# Altium schematic sheet MotorCtrl.SchDoc(MotorCtrl)
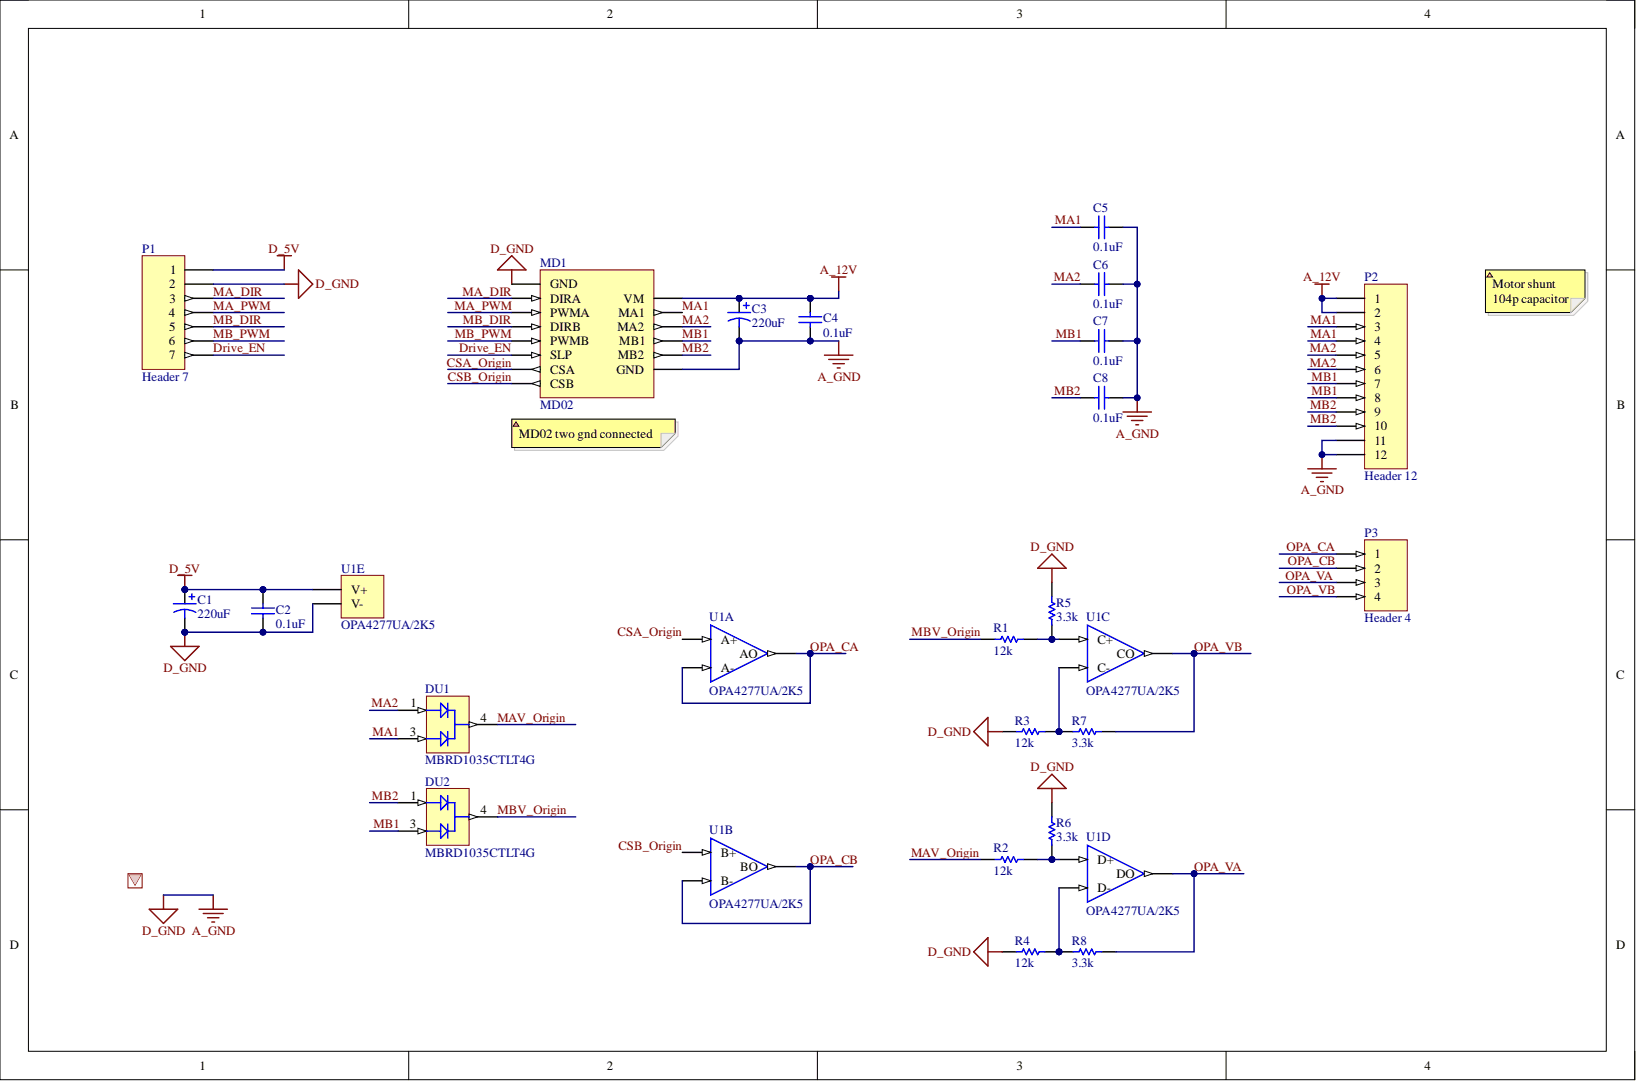
[diagram p0: MotorCtrl.SchDoc]

## Parts
C1  220uF  [VZT221M1ETR-0607]
C2  0.1uF  [CL10B104KA8NNNC]
C3  220uF  [VZT221M1ETR-0607]
C4  0.1uF  [CL10B104KA8NNNC]
C5  0.1uF  [CL10B104KA8NNNC]
C6  0.1uF  [CL10B104KA8NNNC]
C7  0.1uF  [CL10B104KA8NNNC]
C8  0.1uF  [CL10B104KA8NNNC]
DU1  MBRD1035CTLT4G  [MBRD1035CTLT4G]
DU2  MBRD1035CTLT4G  [MBRD1035CTLT4G]
MD1  MD02  [MD02]
P1  Header 7  [KH-2.54PH180-1X7P-L11.5]
P2  Header 12  [KH-2.54PH180-1X12P-L11.5 (Pad Linked)]
P3  Header 4  [KH-2.54PH180-1X4P-L11.5]
R1  12k  [PTFR0603B12K0N9]
R2  12k  [PTFR0603B12K0N9]
R3  12k  [PTFR0603B12K0N9]
R4  12k  [PTFR0603B12K0N9]
R5  3.3k  [0603WAF3301T5E]
R6  3.3k  [0603WAF3301T5E]
R7  3.3k  [0603WAF3301T5E]
R8  3.3k  [0603WAF3301T5E]
U1  OPA4277UA/2K5  [OPA4277UA/2K5]  (parts A,B,C,D,E)

## Nets
A_12V: C3.1 C4.2 MD1.9(VM) P2.1 P2.2
A_GND: C1.2 C2.1 C3.2 C4.1 C5.1 C6.1 C7.1 C8.1 MD1.1(GND) MD1.14(GND) P1.2 P2.11 P2.12 R3.2 R4.2 R5.2 R6.2 U1.11(V-)
D_5V: C1.1 C2.2 P1.1 U1.4(V+)
CSA_Origin: MD1.7(CSA) U1.3(A+)
CSB_Origin: MD1.8(CSB) U1.5(B+)
Drive_EN: MD1.6(SLP) P1.7
MA1: C5.2 DU1.3 MD1.10(MA1) P2.3 P2.4
MA2: C6.2 DU1.1 MD1.11(MA2) P2.5 P2.6
MA_DIR: MD1.2(DIRA) P1.3
MA_PWM: MD1.3(PWMA) P1.4
MAV_Origin: DU1.4 R2.2
MB1: C7.2 DU2.3 MD1.12(MB1) P2.7 P2.8
MB2: C8.2 DU2.1 MD1.13(MB2) P2.9 P2.10
MB_DIR: MD1.4(DIRB) P1.5
MB_PWM: MD1.5(PWMB) P1.6
MBV_Origin: DU2.4 R1.2
OPA_CA: P3.1 U1.1(AO) U1.2(A-)
OPA_CB: P3.2 U1.6(B-) U1.7(BO)
OPA_VA: P3.3 R8.1 U1.14(DO)
OPA_VB: P3.4 R7.1 U1.8(CO)
NetR1_1: R1.1 R5.1 U1.10(C+)
NetR2_1: R2.1 R6.1 U1.12(D+)
NetR3_1: R3.1 R7.2 U1.9(C-)
NetR4_1: R4.1 R8.2 U1.13(D-)

## Library data of the parts
C1 C3 = Cap Pol1: -45℃~+105℃ 2000hrs@105℃ 220uF 7.7mm 25V 6.3mm ±20% SMD,6.3x7.7mm  Aluminum Electrolytic Capacitors - SMD ROHS; Component Kind: Standard; LatestRevisionDate: 17-Jul-2002; LatestRevisionNote: Re-released for DXP Platform.; Library Name: Miscellaneous Devices.IntLib; PackageDescription: Polarized Capacitor (Radial); 2 Leads; PackageReference: RB7.6-15; Published: 8-Jun-2000; Signal Integrity: Cap Pol; Simulation: CAP; Publisher: Altium Limited
C2 C4-C8 = Cap Semi: 25V 100nF X7R ±10% 0603  Multilayer Ceramic Capacitors MLCC - SMD/SMT ROHS; Component Kind: Standard; LatestRevisionDate: 29-May-2009; LatestRevisionNote: IPC-7351 Footprint Added.; Library Name: Miscellaneous Devices.IntLib; PackageDescription: Chip Capacitor; PackageReference: C1206; Published: 8-Jun-2000; Signal Integrity: Cap Semi; Simulation: CAP; Publisher: Altium Limited
DU1 DU2 = MBRD1035CTLT4G: 35V 470mV@5A 5A TO-252-2(DPAK) Schottky Barrier Diodes (SBD) ROHS; buy_link: https://www.ruten.com.tw/item/show?21744290003226; Component Kind: Standard; datasheet: https://datasheet.ciiva.com/905/mbrd1035ctl-d-905952.pdf?src-supplier=Digi-Key; Library Name: OWMR.SchLib
MD1 = MD02 Motor Driver: MD02 17A 2 cheel motor driver; buy_link: https://www.ruten.com.tw/item/show?21811773783671; Component Kind: Standard; Library Name: OWMR.SchLib
P1 = Header 7: 3A Straight Square Pins 2.5mm 6mm -40℃~+105℃ 1 7 3mm 2.54mm Black 1x7P Plugin,P=2.54mm  Pin Headers ROHS; Component Kind: Standard; LatestRevisionDate: 17-Jul-2002; LatestRevisionNote: Re-released for DXP Platform.; Library Name: Miscellaneous Connectors.IntLib; Signal Integrity: Connector; Publisher: Altium Limited
P2 = Header 12: 3A Straight Square Pins 2.5mm 6mm -40℃~+105℃ 1 12 3mm 2.54mm Black 1x12P Plugin,P=2.54mm  Pin Headers ROHS; Component Kind: Standard; LatestRevisionDate: 17-Jul-2002; LatestRevisionNote: Re-released for DXP Platform.; Library Name: Miscellaneous Connectors.IntLib; Signal Integrity: Connector; Publisher: Altium Limited
P3 = Header 4: 3A Straight Square Pins 2.5mm 6mm -40℃~+105℃ 1 4 3mm 2.54mm Black 1x4P Plugin,P=2.54mm  Pin Headers ROHS; Component Kind: Standard; LatestRevisionDate: 17-Jul-2002; LatestRevisionNote: Re-released for DXP Platform.; Library Name: Miscellaneous Connectors.IntLib; Signal Integrity: Connector; Publisher: Altium Limited
R1-R8 = Res1: Resistor; Component Kind: Standard; LatestRevisionDate: 17-Jul-2002; LatestRevisionNote: Re-released for DXP Platform.; Library Name: Miscellaneous Devices.IntLib; PackageDescription: Axial Device, Thru-Hole; 2 Leads; 0.3 in Pin Spacing; PackageReference: AXIAL-0.3; Published: 8-Jun-2000; Signal Integrity: Res; Simulation: RESISTOR; Publisher: Altium Limited
U1 = OPA4277UA/2K5: 790μA 1MHz 4 4V~36V,±2V~18V 0.8 V/us General Purpose SOIC-14  Precision OpAmps ROHS; Rule: Width Constraint [Pref Width = 0.2mm    Min Width = 0.1mm    Max Width = 0.6mm]; Component Kind: Standard; Library Name: OWMR.SchLib
